# Altium schematic sheet Sheet1.SchDoc
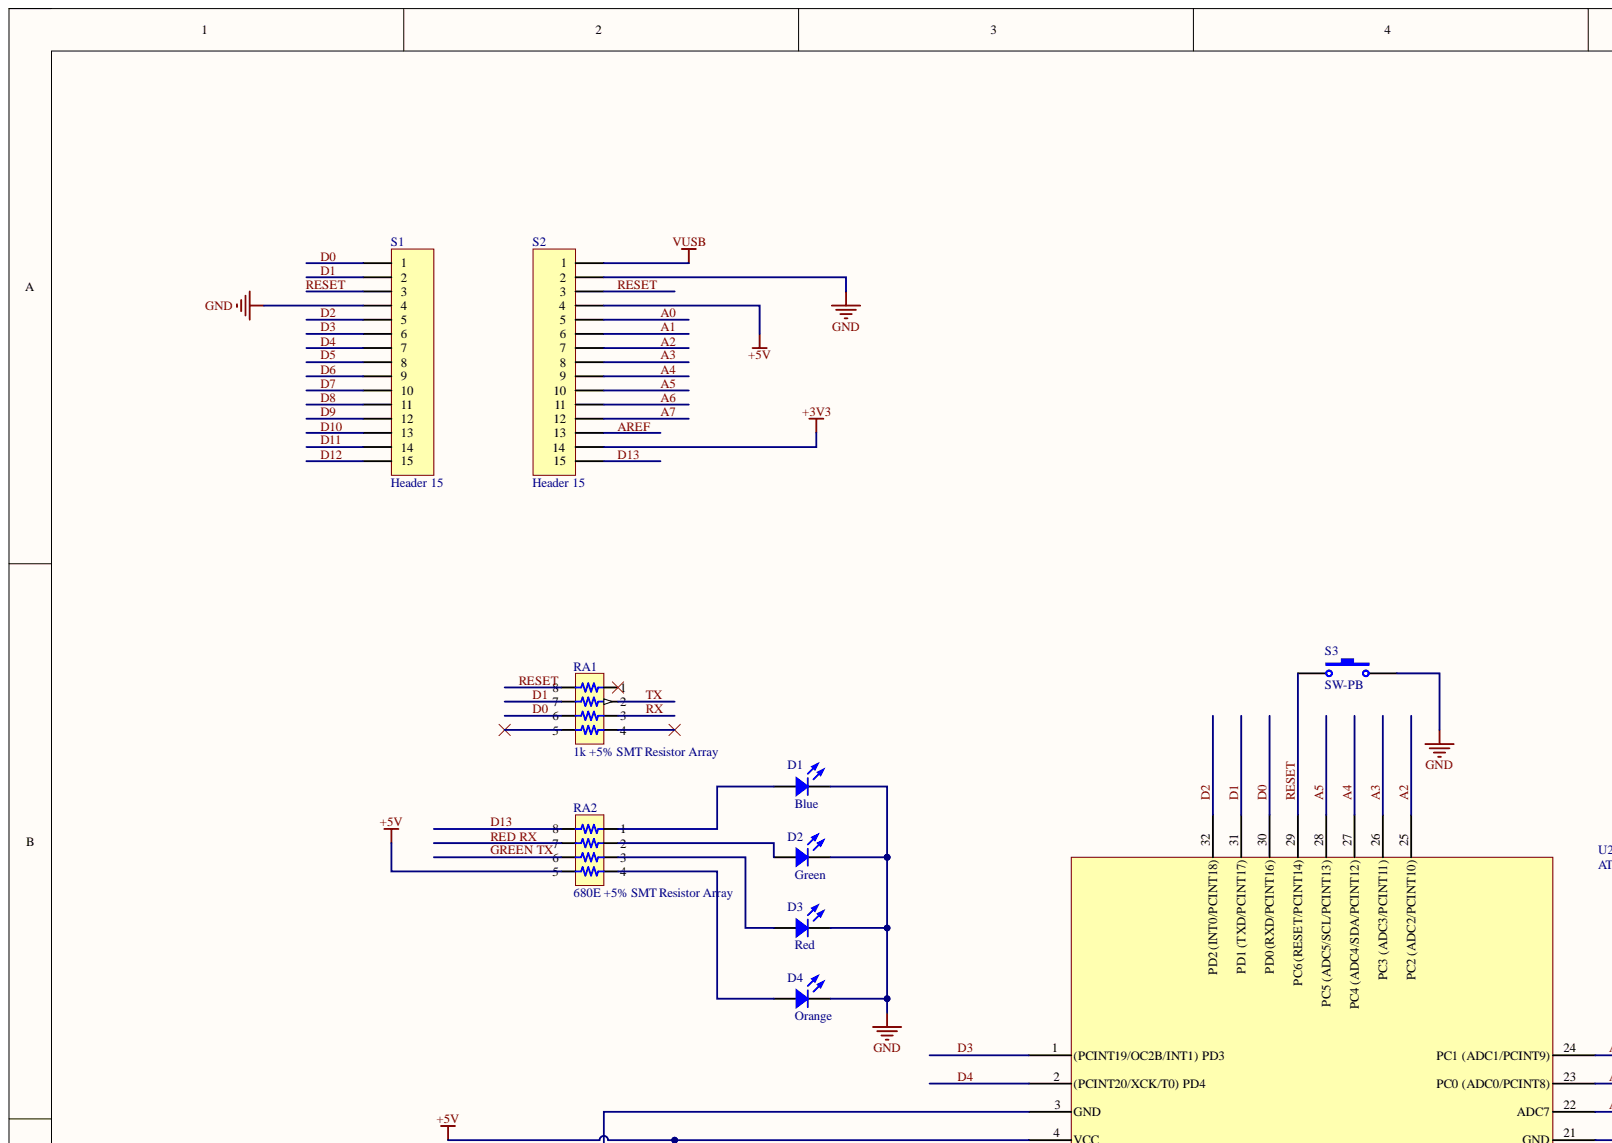
[diagram p0: Sheet1.SchDoc, top left]
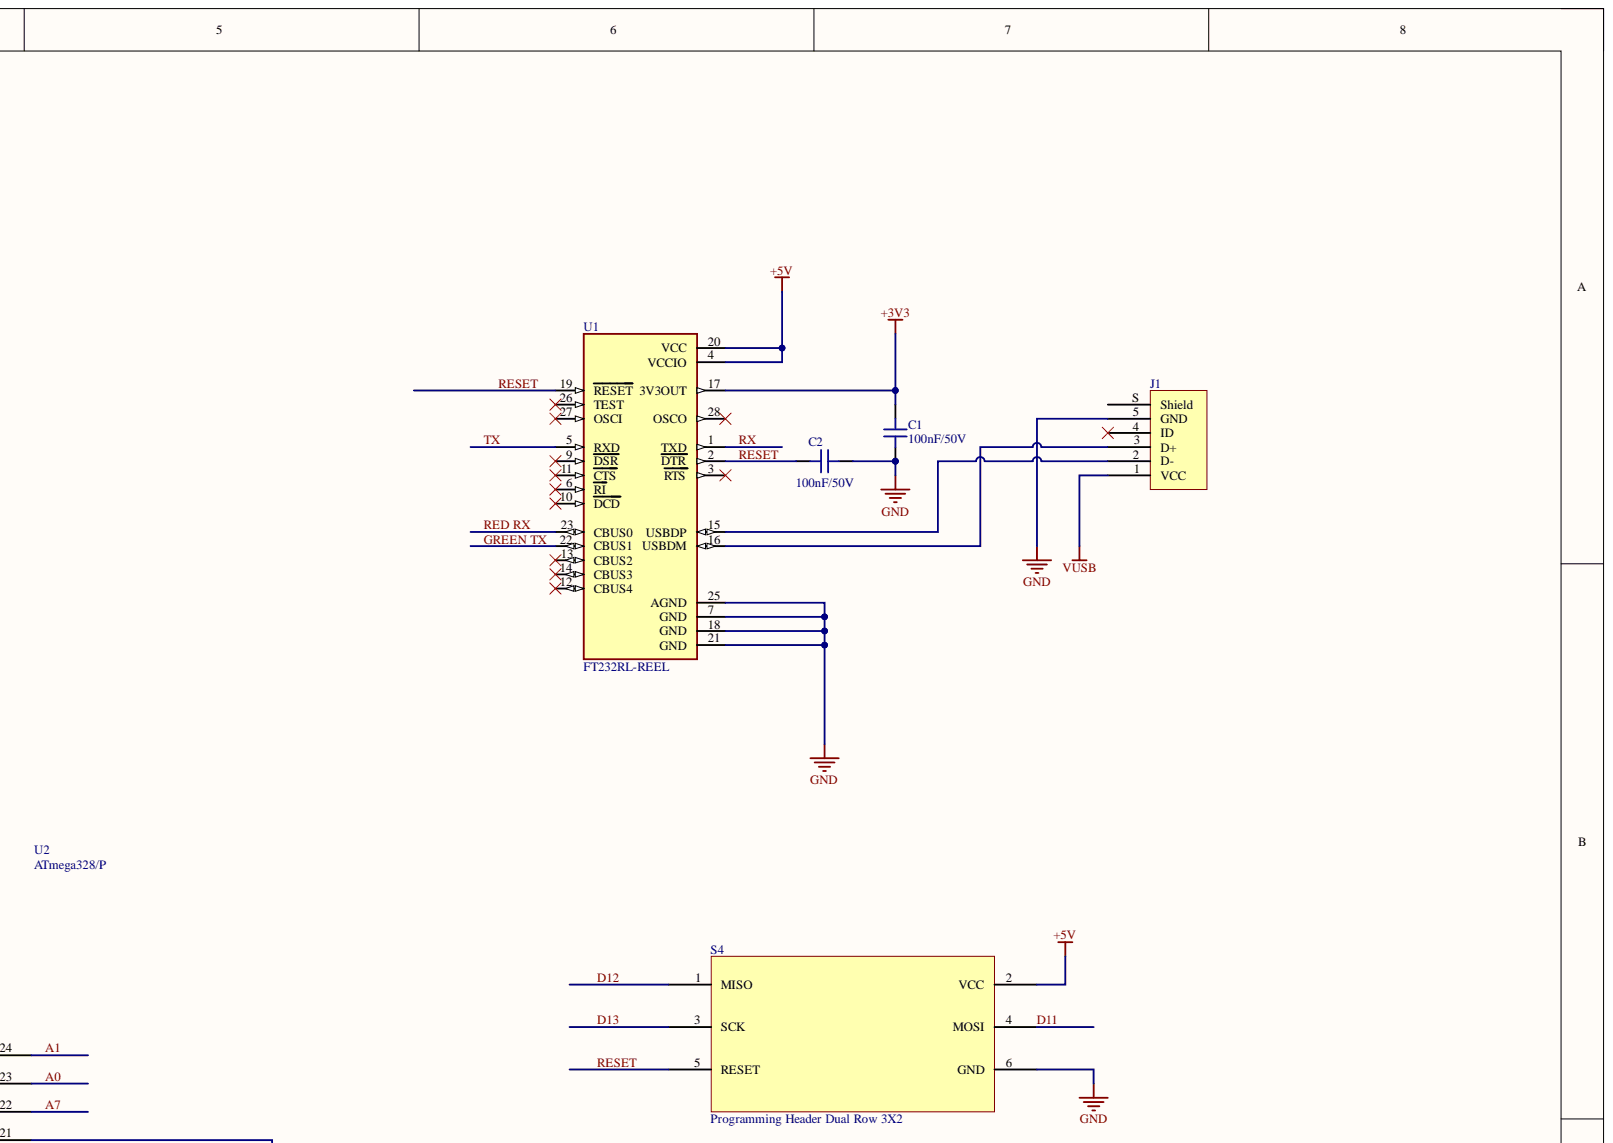
[diagram p1: Sheet1.SchDoc, top right]
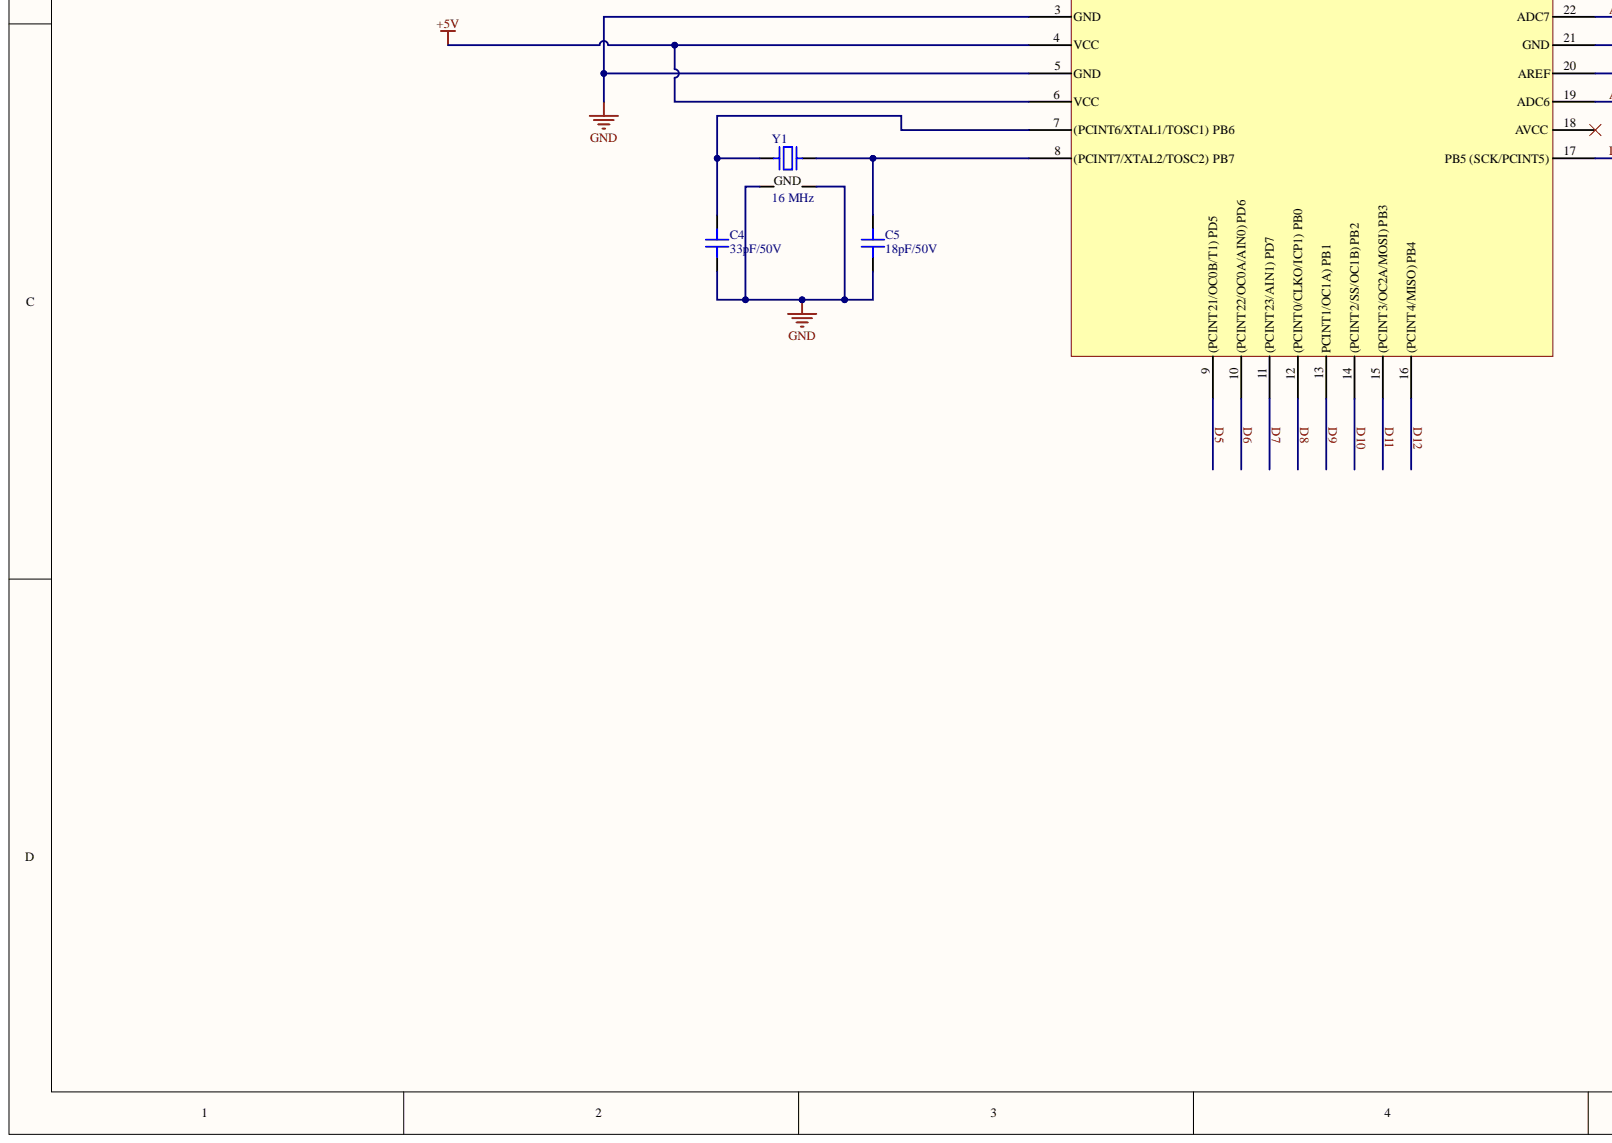
[diagram p2: Sheet1.SchDoc, bottom left]
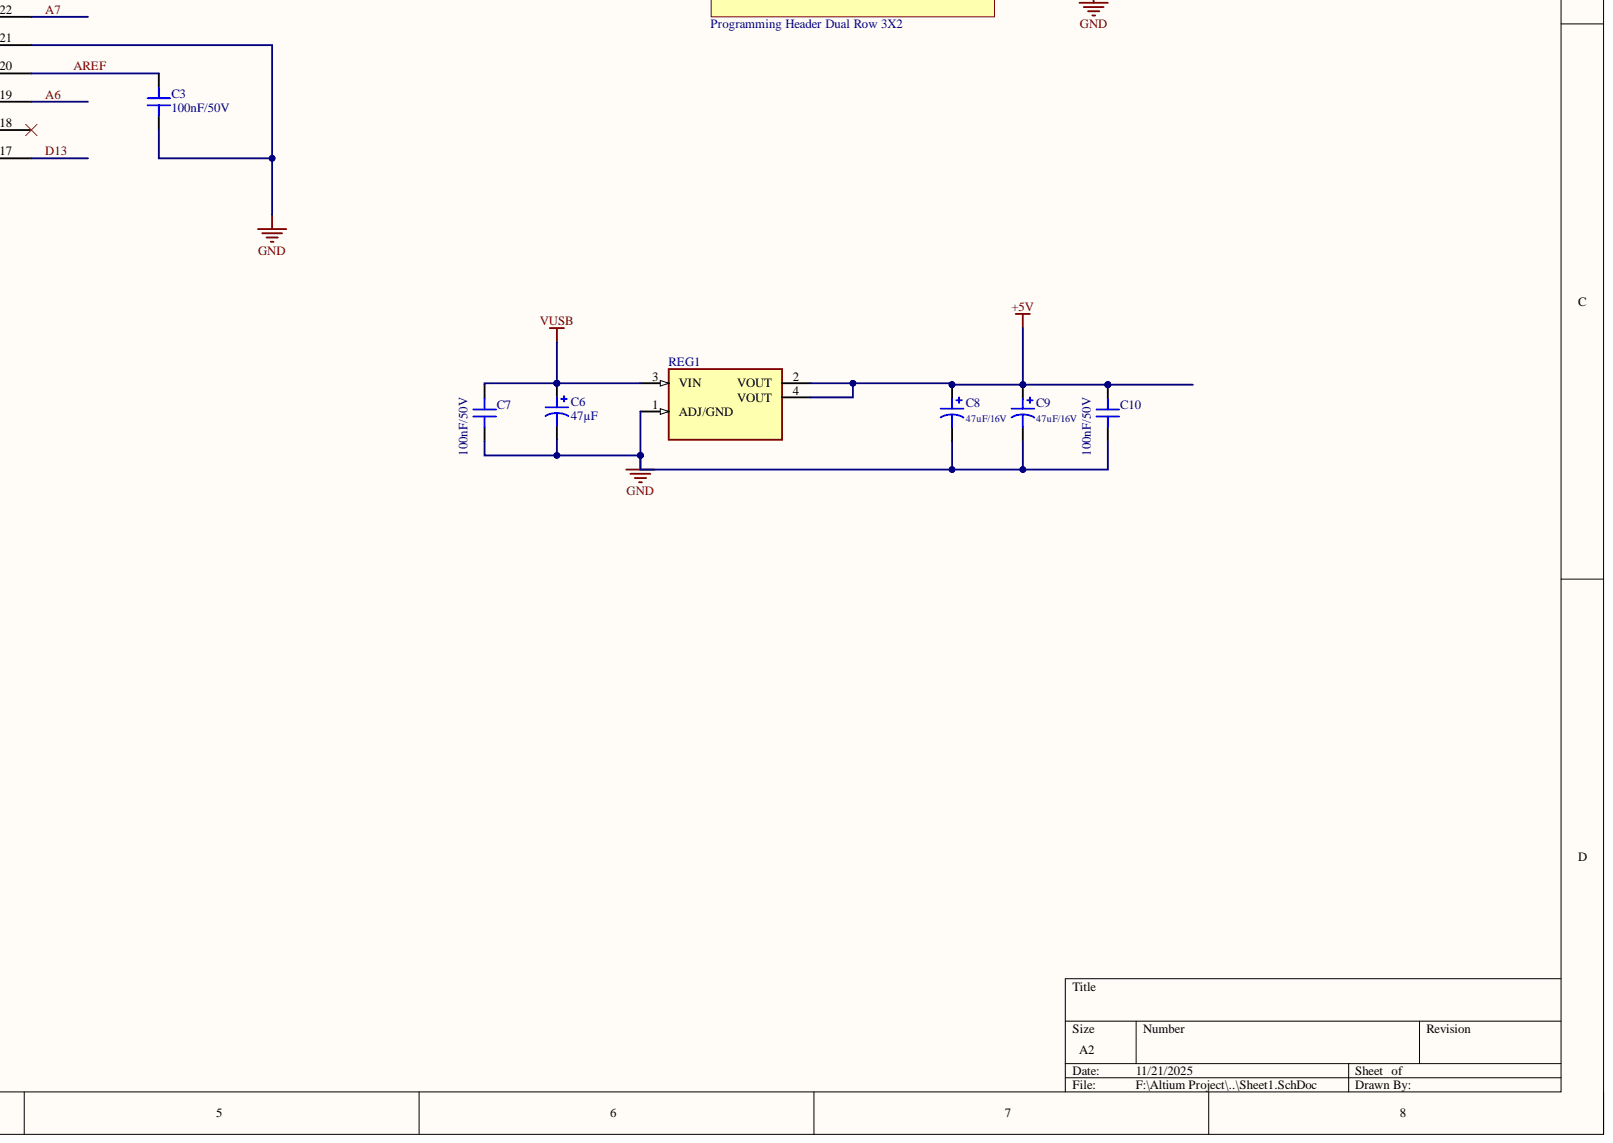
[diagram p3: Sheet1.SchDoc, bottom right]

## Parts
C1  100nF/50V  [CAP 0805_2012]
C2  100nF/50V  [CAP 0805_2012]
C3  100nF/50V  [CAP 0805_2012]
C4  33pF/50V  [CAP 0805_2012]
C5  18pF/50V  [CAP 0805_2012]
C6  47µF  [CAP TH ALUM ELEC D6.30mm S2.50mm H12.00mm]
C7  100nF/50V  [CAP 0805_2012]
C8  47uF/16V  [CAP TH ALUM ELEC D6.30mm S2.50mm H12.00mm]
C9  47uF/16V  [CAP TH ALUM ELEC D6.30mm S2.50mm H12.00mm]
C10  100nF/50V  [CAP 0805_2012]
D1  Blue  [LED 1206_3216 BLUE]
D2  Green  [LED 1206_3216 GREEN]
D3  Red  [LED 1206_3216 RED]
D4  Orange  [LED 1206_3216 RED]
J1  10033526-N3212LF  [FCI USB 10033526-N3212LF]  (value not drawn)
RA1  1k +5% SMT Resistor Array  Resistance (Ohms): 1k  [RESNET 1206-8 CONCAVE]
RA2  680E +5% SMT Resistor Array  [RESNET 1206-8 CONVEX]
REG1  LM1117IMPX-5.0  [SOT230P700X180-4N]  (value not drawn)
S1  Header 15  [HDR1X15]
S2  Header 15  [HDR1X15]
S3  SW-PB  [SPST-2]
S4  Programming Header Dual Row 3X2  [Header 3X2]
U1  FT232RL-REEL  [SOP65P780X200-28N]
U2  ATmega328/P  [TQFP80P900X900X120-32N]
Y1  16 MHz  [NDK NX2520SA]

## Nets
+3V3: C1.2 S2.14 U1.17(3V3OUT)
+5V: C8.1 C9.1 C10.1 RA2.4 REG1.2(VOUT) REG1.4(VOUT) S2.4 S4.2(VCC) U1.4(VCCIO) U1.20(VCC) U2.4(VCC) U2.6(VCC)
GND: C1.1 C2.2 C3.1 C4.1 C5.1 C6.2 C7.2 C8.2 C9.2 C10.2 D1.2 D2.2 D3.2 D4.2 J1.5(GND) REG1.1(ADJ/GND) S1.4 S2.2 S3.2 S4.6(GND) U1.7(GND) U1.18(GND) U1.21(GND) U1.25(AGND) U2.3(GND) U2.5(GND) U2.21(GND) Y1.2 Y1.4
NetRA1: RA1.
VUSB: C6.1 C7.1 J1.1(VCC) REG1.3(VIN) S2.1
A0: S2.5 U2.23(PC0_(ADC0/PCINT8))
A1: S2.6 U2.24(PC1_(ADC1/PCINT9))
A2: S2.7 U2.25(PC2_(ADC2/PCINT10))
A3: S2.8 U2.26(PC3_(ADC3/PCINT11))
A4: S2.9 U2.27(PC4_(ADC4/SDA/PCINT12))
A5: S2.10 U2.28(PC5_(ADC5/SCL/PCINT13))
A6: S2.11 U2.19(ADC6)
A7: S2.12 U2.22(ADC7)
AREF: C3.2 S2.13 U2.20(AREF)
D0: RA1.3 S1.1 U2.30(PD0_(RXD/PCINT16))
D1: RA1.2 S1.2 U2.31(PD1_(TXD/PCINT17))
D2: S1.5 U2.32(PD2_(INT0/PCINT18))
D3: S1.6 U2.1((PCINT19/OC2B/INT1)_PD3)
D4: S1.7 U2.2((PCINT20/XCK/T0)_PD4)
D5: S1.8 U2.9((PCINT21/OC0B/T1)_PD5)
D6: S1.9 U2.10((PCINT22/OC0A/AIN0)_PD6)
D7: S1.10 U2.11((PCINT23/AIN1)_PD7)
D8: S1.11 U2.12((PCINT0/CLKO/ICP1)_PB0)
D9: S1.12 U2.13(PCINT1/OC1A)_PB1)
D10: S1.13 U2.14((PCINT2/SS/OC1B)_PB2)
D11: S1.14 S4.4(MOSI) U2.15((PCINT3/OC2A/MOSI)_PB3)
D12: S1.15 S4.1(MISO) U2.16((PCINT4/MISO)_PB4)
D13: RA2.1 S2.15 S4.3(SCK) U2.17(PB5_(SCK/PCINT5))
GREEN TX: RA2.3 U1.22(CBUS1)
RED RX: RA2.2 U1.23(CBUS0)
RESET: C2.1 RA1.1 S1.3 S2.3 S3.1 S4.5(RESET) U1.2(DTR) U1.19(RESET) U2.29(PC6_(RESET/PCINT14))
RX: RA1.6 U1.1(TXD)
TX: RA1.7 U1.5(RXD)
NetC4_2: C4.2 U2.7((PCINT6/XTAL1/TOSC1)_PB6) Y1.1
NetC5_2: C5.2 U2.8((PCINT7/XTAL2/TOSC2)_PB7) Y1.3
NetD1_1: D1.1 RA2.8
NetD2_1: D2.1 RA2.7
NetD3_1: D3.1 RA2.6
NetD4_1: D4.1 RA2.5
NetJ1_2: J1.2(D-) U1.15(USBDP)
NetJ1_3: J1.3(D+) U1.16(USBDM)

Not connected: J1 4(ID) S(Shield); RA1 4 5; U1 3(RTS) 6(RI) 9(DSR) 10(DCD) 11(CTS) 12(CBUS4) 13(CBUS2) 14(CBUS3) 26(TEST) 27(OSCI) 28(OSCO); U2 18(AVCC)

## Library data of the parts
C1-C3 C7 C10 = 08055D104KAT2A: CAP CER 0.1UF 50V X5R 0805; manufacturer: AVX Corporation; Database Table Name: Capacitors - Ceramic - 0805; Tolerance: ±10%; value: 0.1µF; Applications: General Purpose; Component Kind: Standard; componentlink1description: Datasheet; componentlink1url: http://datasheets.avx.com/cx5r.pdf; componentlink2description: DigiKey Link; componentlink2url: http://digikey.com/product-detail/en/avx-corporation/08055D104KAT2A/478-12790-1-ND/9949330; Device Package: 0805 (2012 Metric); lastupdated: 2/9/2020 1:04:29 am; Library Name: Celestial Altium Library - altium_library.DbLib; Lifecycle Status: Active; Minimum Order: 1; Mounting Type: Surface Mount, MLCC; Operating Temperature: -55°C ~ 85°C; Package / Case: 0805 (2012 Metric); packaging: TapeAndReel; Part Number: 08055D104KAT2A; Part Status: Active; partid: 9440; price: 0.02; series: -; Size / Dimension: 0.079" L x 0.049" W (2.01mm x 1.25mm); Supplier 1: DigiKey; Supplier Part Number 1: 478-12790-1-ND; Temperature Coefficient: X5R; Thickness (Max): 0.055" (1.40mm); Voltage - Rated: 50V
C4 C5 = 08055A180JAT2A: CAP CER 18PF 50V C0G/NP0 0805; manufacturer: AVX Corporation; Database Table Name: Capacitors - Ceramic - 0805; Temperature Coefficient: C0G, NP0; Tolerance: ±5%; Applications: General Purpose; Component Kind: Standard; componentlink1description: Datasheet; componentlink1url: http://datasheets.avx.com/C0GNP0-Dielectric.pdf; componentlink2description: DigiKey Link; componentlink2url: http://digikey.com/product-detail/en/avx-corporation/08055A180JAT2A/478-1307-1-ND/564339; Device Package: 0805 (2012 Metric); lastupdated: 2/9/2020 1:04:40 am; Library Name: Celestial Altium Library - altium_library.DbLib; Lifecycle Status: Active; Minimum Order: 1; Mounting Type: Surface Mount, MLCC; Operating Temperature: -55°C ~ 125°C; Package / Case: 0805 (2012 Metric); packaging: TapeAndReel; Part Number: 08055A180JAT2A; Part Status: Active; partid: 3219; price: 0.02; series: -; Size / Dimension: 0.079" L x 0.049" W (2.01mm x 1.25mm); Supplier 1: DigiKey; Supplier Part Number 1: 478-1307-1-ND; Thickness (Max): 0.037" (0.94mm); value: 18pF; Voltage - Rated: 50V
C6 = UKL1C470KEDANA: CAP 47UF 16VFC 10-% TOL10+% RADI; manufacturer: Nichicon; Database Table Name: Capacitors - Aluminum - Electrolytic TH; Lifetime @ Temp.: 1000 Hrs @ 105°C; Polarization: Polar; Ripple Current @ Low Frequency: 140mA @ 120Hz; Tolerance: ±10%; Voltage - Rated: 16V; Applications: General Purpose; Capacitance: 47µF; Component Kind: Standard; componentlink1description: Datasheet; componentlink1url: http://nichicon-us.com/english/products/pdfs/e-ukl.pdf; componentlink2description: DigiKey Link; componentlink2url: http://digikey.com/product-detail/en/nichicon/UKL1C470KEDANA/493-16225-ND/819580; Device Package: Radial, Can; Height - Seated (Max): 0.472" (12.00mm); lastupdated: 4/9/2020 1:05:44 am; Lead Spacing: 0.098" (2.50mm); Library Name: Celestial Altium Library - altium_library.DbLib; Lifecycle Status: Active; Minimum Order: 1; Mounting Type: Through Hole; Operating Temperature: -40°C ~ 105°C; Package / Case: Radial, Can; packaging: Unknown; Part Number: UKL1C470KEDANA; Part Status: Active; partid: 20080; price: 0.46; series: UKL; Size / Dimension: 0.248" Dia (6.30mm); Supplier 1: DigiKey; Supplier Part Number 1: 493-16225-ND; value: 47µF
C8 C9 = UKL1C470KEDANA: CAP 47UF 16VFC 10-% TOL10+% RADI; manufacturer: Nichicon; Capacitance: 47µF; Database Table Name: Capacitors - Aluminum - Electrolytic TH; Lifetime @ Temp.: 1000 Hrs @ 105°C; Polarization: Polar; Ripple Current @ Low Frequency: 140mA @ 120Hz; Tolerance: ±10%; value: 47µF; Voltage - Rated: 16V; Applications: General Purpose; Component Kind: Standard; componentlink1description: Datasheet; componentlink1url: http://nichicon-us.com/english/products/pdfs/e-ukl.pdf; componentlink2description: DigiKey Link; componentlink2url: http://digikey.com/product-detail/en/nichicon/UKL1C470KEDANA/493-16225-ND/819580; Device Package: Radial, Can; Height - Seated (Max): 0.472" (12.00mm); lastupdated: 4/9/2020 1:05:44 am; Lead Spacing: 0.098" (2.50mm); Library Name: Celestial Altium Library - altium_library.DbLib; Lifecycle Status: Active; Minimum Order: 1; Mounting Type: Through Hole; Operating Temperature: -40°C ~ 105°C; Package / Case: Radial, Can; packaging: Unknown; Part Number: UKL1C470KEDANA; Part Status: Active; partid: 20080; price: 0.46; series: UKL; Size / Dimension: 0.248" Dia (6.30mm); Supplier 1: DigiKey; Supplier Part Number 1: 493-16225-ND
D1 = APT3216QBC/D: LED BLUE CLEAR CHIP SMD; Manufacturer: Kingbright; Configuration: Standard; Current - Test: 20mA; Database Table Name: LED - Indication; Lens Color: Colorless; Lens Size: 2.00mm x 1.60mm; Lens Style: Rectangle with Flat Top; Millicandela Rating: 100mcd; Viewing Angle: 120°; Voltage - Forward (Vf) (Typ): 3.3V; Wavelength - Dominant: 465nm; Wavelength - Peak: 460nm; Color: Blue; Component Kind: Standard; ComponentLink1Description: Datasheet; ComponentLink1URL: http://www.kingbrightusa.com/images/catalog/SPEC/APT3216QBC-D.pdf; ComponentLink2Description: DigiKey Link; ComponentLink2URL: https://www.digikey.com/en/products/detail/kingbright/APT3216QBC-D/2163702; Device Package: 1206 (3216 Metric); Height (Max): 0.75mm; LastUpdated: 3/6/2024 7:58:07 pm; Lens Transparency: Clear; Library Name: Celestial Altium Library - altium_library.DbLib; Lifecycle Status: Active; Minimum Order: 1; Mounting Type: Surface Mount; Package / Case: 1206 (3216 Metric); Packaging: TapeAndReel; Part Number: APT3216QBC/D; Part Status: Active; PartId: 730; Price: 0.09; Series: -; Size / Dimension: 3.20mm L x 1.60mm W; Supplier 1: DigiKey; Supplier Device Package: Chip LED; Supplier Part Number 1: 754-1439-1-ND; Value: Blue
D2 = 5976325607F: LED GREEN 1206 SMD; Manufacturer: Dialight; Configuration: Standard; Current - Test: 20mA; Database Table Name: LED - Indication; Lens Color: 1.23mm; Lens Size: 1.60mm Dia; Lens Style: Round with Domed Top; Viewing Angle: 70°; Voltage - Forward (Vf) (Typ): 3.3V; Wavelength - Peak: 530nm; Color: Green; Component Kind: Standard; ComponentLink1Description: Datasheet; ComponentLink1URL: //media.digikey.com/pdf/Data%20Sheets/Dialight%20PDFs/5976325607F.pdf; ComponentLink2Description: DigiKey Link; ComponentLink2URL: http://digikey.com/product-detail/en/dialight/5976325607F/350-4393-1-ND/9385499; Device Package: 1206 (3216 Metric); Height (Max): 1.23mm; LastUpdated: 2/9/2020 1:20:47 am; Library Name: Celestial Altium Library - altium_library.DbLib; Lifecycle Status: Active; Minimum Order: 1; Mounting Type: Surface Mount; Package / Case: 1206 (3216 Metric); Packaging: TapeAndReel; Part Number: 5976325607F; Part Status: Active; PartId: 533; Price: 0.28; Series: 597; Size / Dimension: 3.20mm L x 1.60mm W; Supplier 1: DigiKey; Supplier Device Package: 1206; Supplier Part Number 1: 350-4393-1-ND; Value: Green
D3 D4 = 5990210007F: LED RED 1206 SMD; Manufacturer: Dialight; Configuration: Standard; Current - Test: 20mA; Database Table Name: LED - Indication; Lens Color: 0.80mm; Lens Size: 2.00mm x 1.60mm; Lens Style: Rectangle with Flat Top; Millicandela Rating: 29mcd; Viewing Angle: 140°; Voltage - Forward (Vf) (Typ): 2.1V; Wavelength - Dominant: 633nm; Color: Red; Component Kind: Standard; ComponentLink1Description: Datasheet; ComponentLink1URL: http://www.dialightsignalsandcomponents.com/Assets/Brochures_And_Catalogs/Indication/CBI_SMD_new/Dialight_CBI_data_599-1206_Apr2018.pdf; ComponentLink2Description: DigiKey Link; ComponentLink2URL: https://www.digikey.com/en/products/detail/dialight/5990210007F/9385415; Device Package: 1206 (3216 Metric); Height (Max): 0.80mm; LastUpdated: 3/6/2024 8:00:41 pm; Library Name: Celestial Altium Library - altium_library.DbLib; Lifecycle Status: Active; Minimum Order: 1; Mounting Type: Surface Mount; Package / Case: 1206 (3216 Metric); Packaging: TapeAndReel; Part Number: 5990210007F; Part Status: Active; PartId: 188; Price: 0.04; Series: 599; Size / Dimension: 3.20mm L x 1.60mm W; Supplier 1: DigiKey; Supplier Device Package: 1206; Supplier Part Number 1: 350-4360-1-ND; Value: Red
J1 = 10033526-N3212LF: CONN RCPT MINI USB B 5POS SMD RA; Manufacturer: Amphenol ICC (FCI); Connector Type: USB - mini B; Current Rating (Amps): 1A per Contact; Database Table Name: Connectors - USB DVI HDMI; Gender: Receptacle; Mating Cycles: 5000; Number of Contacts: 5; Number of Ports: 1; Shielding: Shielded; Voltage - Rated: 30V; Component Kind: Standard; ComponentLink1Description: Datasheet; ComponentLink1URL: http://www.amphenol-icc.com/media/wysiwyg/files/drawing/10033526.pdf; ComponentLink2Description: DigiKey Link; ComponentLink2URL: //digikey.com/product-detail/en/amphenol-icc-fci/10033526-N3212LF/609-4700-2-ND/4292074; Features: Board Guide, Solder Retention; LastUpdated: 9/12/2019 8:52:14 pm; Library Name: Celestial Altium Library - altium_library.DbLib; Lifecycle Status: 0; Minimum Order: 1; Mounting Feature: Horizontal; Mounting Type: Surface Mount, Right Angle; Operating Temperature: -25°C ~ 85°C; Packaging: TapeAndReel; Part Number: 10033526-N3212LF; Part Status: Active; Price: 0.39; Series: -; Supplier 1: DigiKey; Supplier Part Number 1: 609-4700-2-ND; Termination: Solder
RA1 = TC164-JR-071KL: RES ARRAY 4 RES 1K OHM 1206; Manufacturer: Yageo; Circuit Type: Isolated; Database Table Name: Resistors -  Arrays and Networks; Number of Resistors: 4; Power Per Element: 62.5mW; Temperature Coefficient: ±200ppm/°C; Tolerance: ±5%; Applications: DDRAM, SDRAM; Component Kind: Standard; ComponentLink1Description: Datasheet; ComponentLink1URL: https://www.yageo.com/upload/media/product/productsearch/datasheet/rchip/PYu-YC_TC_group_51_RoHS_L_9.pdf; ComponentLink2Description: DigiKey Link; ComponentLink2URL: http://digikey.com/product-detail/en/yageo/TC164-JR-071KL/TC164J-1.0KCT-ND/1005384; Height - Seated (Max): 0.028" (0.70mm); LastUpdated: 2/9/2020 1:23:31 am; Library Name: Celestial Altium Library - altium_library.DbLib; Lifecycle Status: Active; Minimum Order: 1; Mounting Type: Surface Mount; Number of Pins: 8; Operating Temperature: -55°C ~ 155°C; Package / Case: 1206 (3216 Metric), Concave, Long Side Terminals; Packaging: TapeAndReel; Part Number: TC164-JR-071KL; Part Status: Active; PartId: 880; Price: 0.02; Series: TC164; Size / Dimension: 0.126" L x 0.063" W (3.20mm x 1.60mm); Supplier 1: DigiKey; Supplier Part Number 1: TC164J-1.0KCT-ND
RA2 = YC164-JR-07680RL: RES ARRAY 4 RES 680 OHM 1206; Manufacturer: Yageo; Circuit Type: Isolated; Database Table Name: Resistors -  Arrays and Networks; Number of Resistors: 4; Power Per Element: 62.5mW; Resistance (Ohms): 680; Temperature Coefficient: ±200ppm/°C; Tolerance: ±5%; Applications: DDRAM, SDRAM; Component Kind: Standard; ComponentLink1Description: Datasheet; ComponentLink1URL: https://www.yageo.com/upload/media/product/productsearch/datasheet/rchip/PYu-YC_TC_group_51_RoHS_L_9.pdf; ComponentLink2Description: DigiKey Link; ComponentLink2URL: http://digikey.com/product-detail/en/yageo/YC164-JR-07680RL/YC164J-680CT-ND/1005675; Height - Seated (Max): 0.028" (0.70mm); LastUpdated: 24/3/2022 2:30:41 pm; Library Name: Celestial Altium Library - altium_library.DbLib; Lifecycle Status: Active; Minimum Order: 1; Mounting Type: Surface Mount; Number of Pins: 8; Operating Temperature: -55°C ~ 155°C; Package / Case: 1206 (3216 Metric), Convex, Long Side Terminals; Packaging: TapeAndReel; Part Number: YC164-JR-07680RL; Part Status: Active; PartId: 246; Price: 0.01; Series: YC164; Size / Dimension: 0.126" L x 0.063" W (3.20mm x 1.60mm); Supplier 1: DigiKey; Supplier Part Number 1: YC164J-680CT-ND
REG1 = LM1117IMPX-5.0: 800-mA/5V Linear Voltage Regulator; MF: Texas Instruments; MP: LM1117IMPX-5.0/NOPB; Check_prices: https://www.snapeda.com/parts/LM1117IMPX-5.0/NOPB/Texas+Instruments/view-part/?ref=eda; Component Kind: Standard; Library Name: LM1117IMPX-5.0.IntLib; Package: SOT-223-4 Texas Instruments; SnapEDA_Link: https://www.snapeda.com/parts/LM1117IMPX-5.0/NOPB/Texas+Instruments/view-part/?ref=snap
S1 S2 = Header 15: Header, 15-Pin; Component Kind: Standard; LatestRevisionDate: 17-Jul-2002; LatestRevisionNote: Re-released for DXP Platform.; Library Name: Miscellaneous Connectors.IntLib; Signal Integrity: Connector; Publisher: Altium Limited
S3 = SW-PB: Switch; Component Kind: Standard; LatestRevisionDate: 17-Jul-2002; LatestRevisionNote: Re-released for DXP Platform.; Library Name: Miscellaneous Devices.IntLib; PackageDescription: Switch; 2 Leads; PackageReference: SPST-2; Published: 24-Mar-1999; Publisher: Altium Limited
S4 = Header 3X2: Component Kind: Standard; Library Name: Schlib1.SchLib
U1 = FT232RL-REEL: USB Bridge, USB to UART USB 2.0 UART Interface 28-SSOP; MF: FTDI,; Availability: In Stock; Check_prices: https://www.snapeda.com/parts/FT232RL-REEL/FTDI%252C+Future+Technology+Devices+International+Ltd/view-part/?ref=eda; Component Kind: Standard; Library Name: FT232RL-REEL.IntLib; MP: FT232RL-REEL; Package: SSOP-28 FTDI; Price: None; Purchase-URL: https://pricing.snapeda.com/search/part/FT232RL-REEL/?ref=eda; SnapEDA_Link: https://www.snapeda.com/parts/FT232RL-REEL/FTDI%252C+Future+Technology+Devices+International+Ltd/view-part/?ref=snap
Y1 = NX2520SA-16.000000MHZ-W1: CRYSTAL 16.0000MHZ 8PF SMD; Manufacturer: NDK America, Inc.; Database Table Name: Oscillators - Crystals; ESR (Equivalent Series Resistance): 120 Ohms; Frequency Stability: ±20ppm; Operating Mode: Fundamental; Component Kind: Standard; ComponentLink1Description: Datasheet; ComponentLink1URL: //media.digikey.com/pdf/Data Sheets/NDK PDFs/NX2520SA-16.000000MHz-W1.pdf; ComponentLink2Description: DigiKey Link; ComponentLink2URL: https://www.digikey.com/en/products/detail/ndk-america-inc/NX2520SA-16-000000MHZ-W1/1468334; Frequency: 16 MHz; Frequency Tolerance: ±20ppm; Height - Seated (Max): 0.026" (0.65mm); LastUpdated: 3/6/2024 8:02:18 pm; Library Name: Celestial Altium Library - altium_library.DbLib; Lifecycle Status: Active; Load Capacitance: 8pF; Minimum Order: 1; Mounting Type: Surface Mount; Operating Temperature: -40°C ~ 85°C; Package / Case: 4-SMD, No Lead; Packaging: TapeAndReel; Part Number: NX2520SA-16.000000MHZ-W1; Part Status: Active; PartId: 523; Price: 0.77; Series: NX2520SA; Size / Dimension: 0.098" L x 0.079" W (2.50mm x 2.00mm); Supplier 1: DigiKey; Supplier Part Number 1: 644-1093-1-ND; Type: MHz Crystal; Value: 16 MHz
U2 = ATmega328/P: Component Kind: Standard; ComponentLink1Description: Datasheet; ComponentLink1URL: https://datasheet.octopart.com/ATMEGA328-AU-Microchip-datasheet-65729177.pdf; Library Name: Atmega328p.SchLib
